# Altium schematic sheet sem02_2442sd.SchDoc
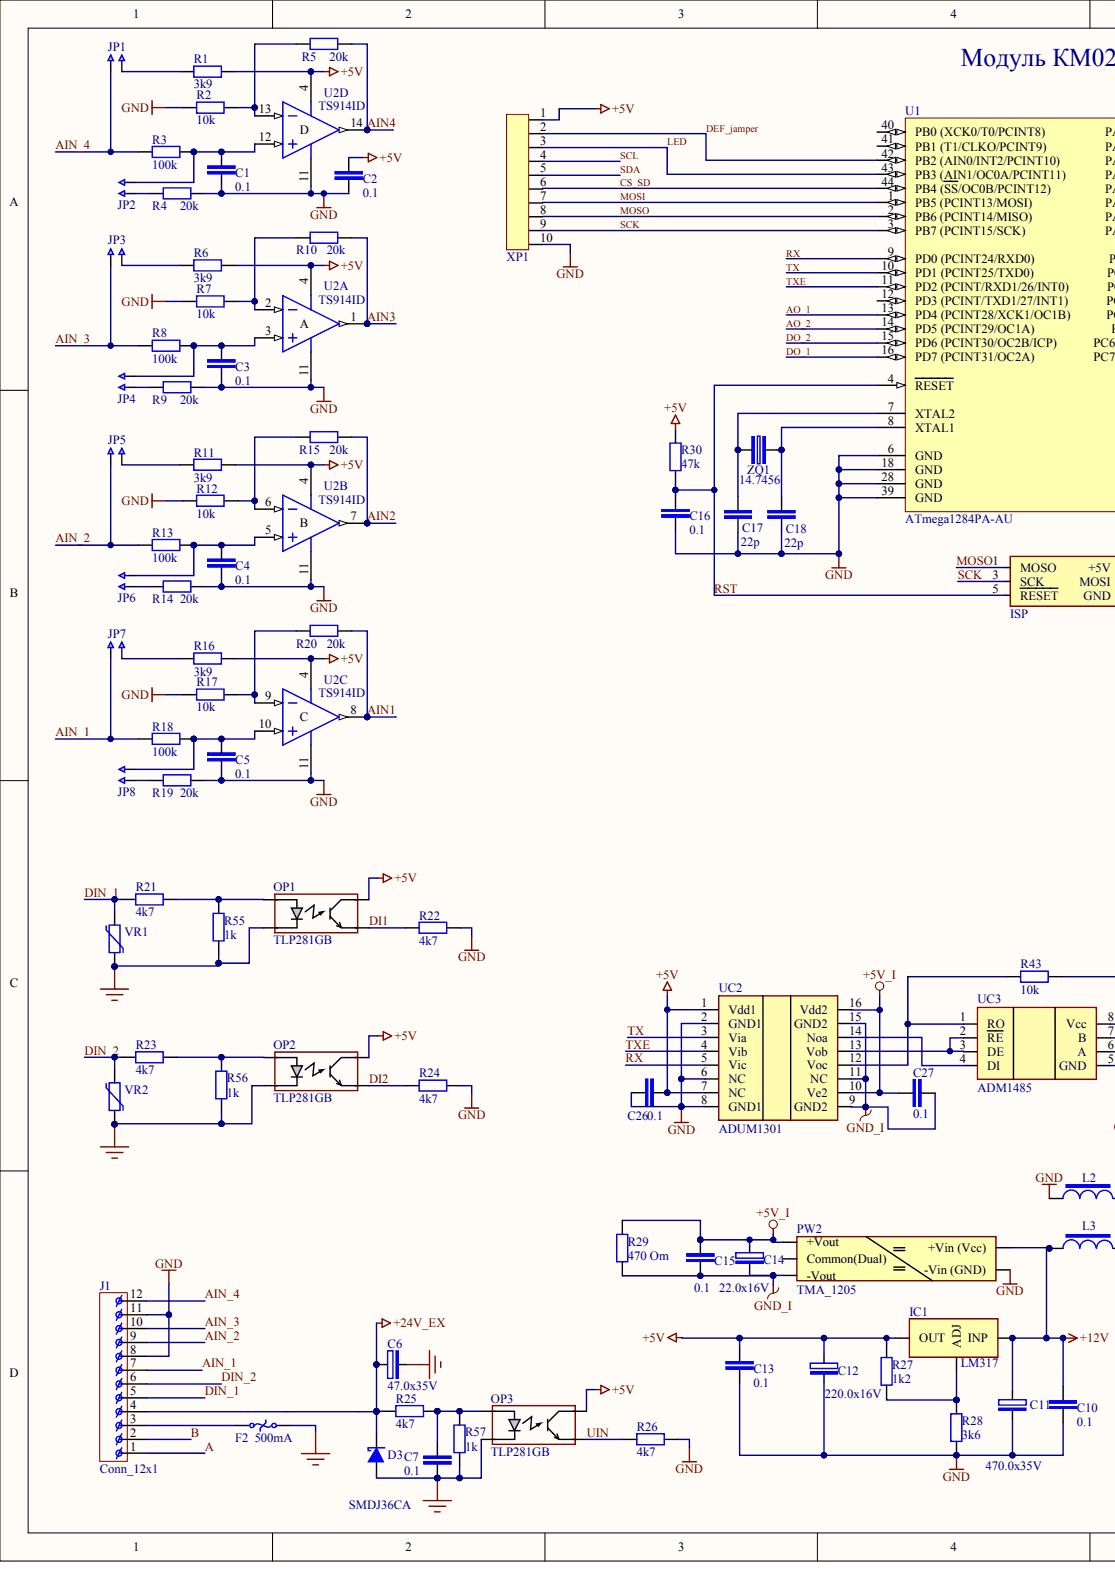
[diagram p0: sem02_2442sd.SchDoc, left]
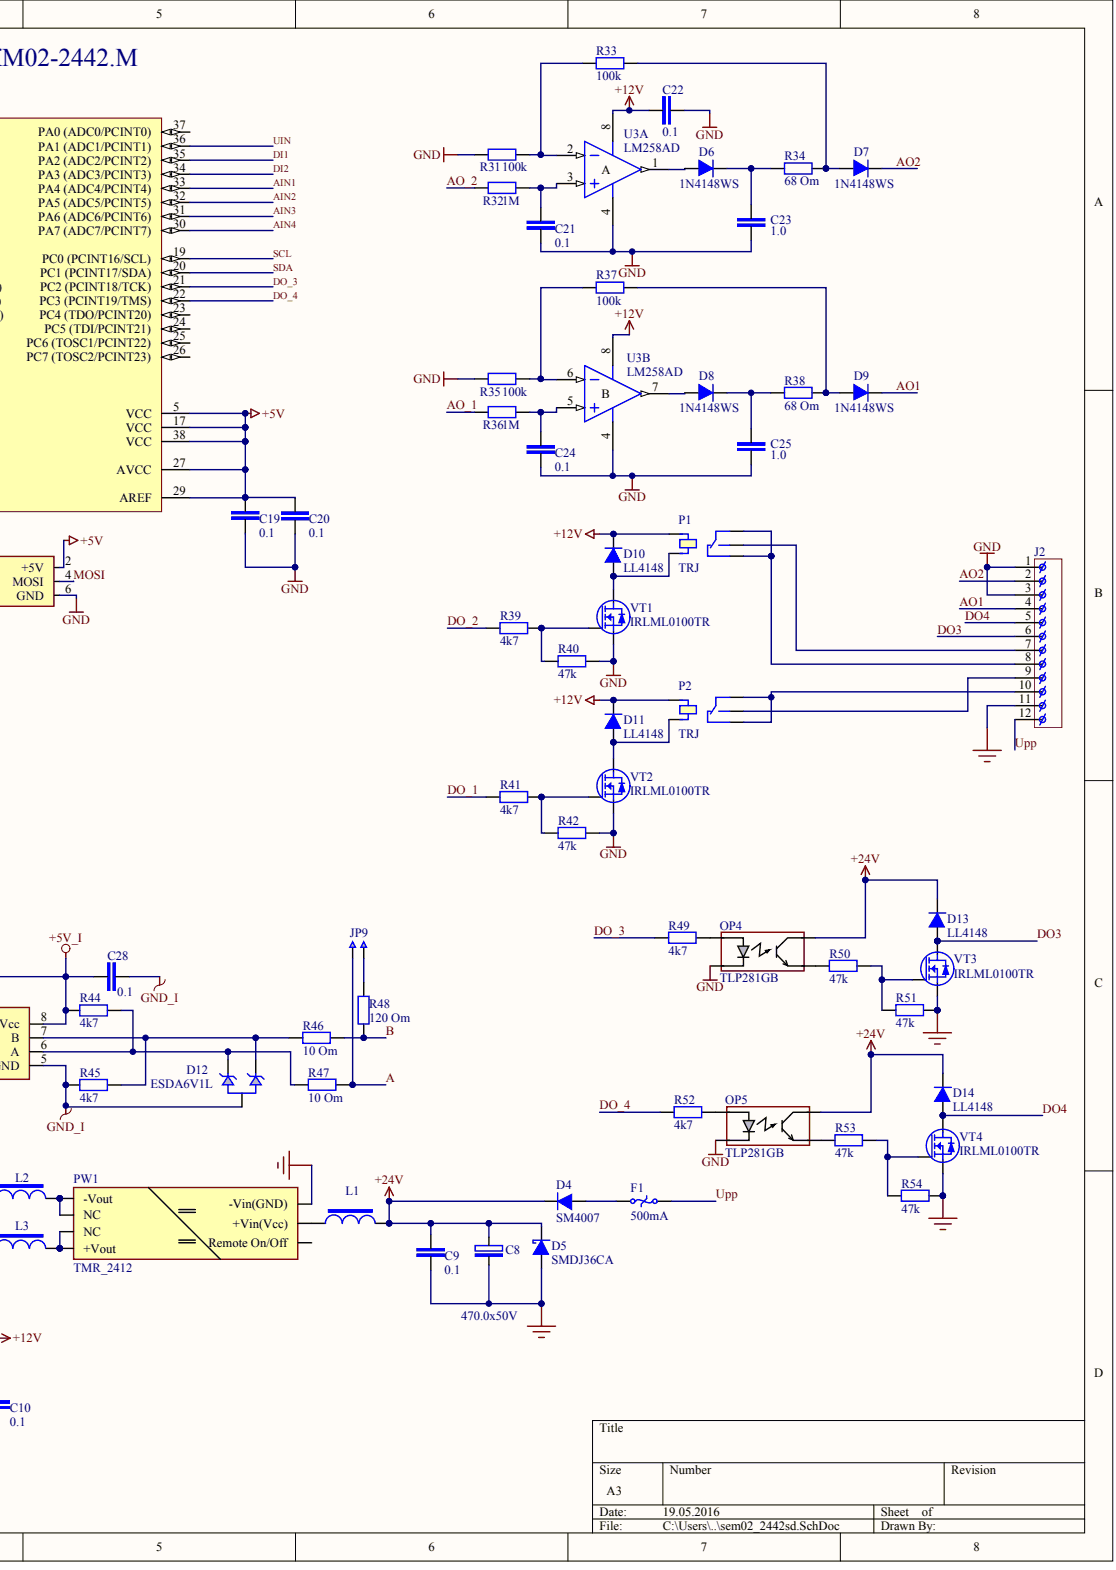
[diagram p1: sem02_2442sd.SchDoc, right]

## Parts
C1  0.1
C2  0.1
C3  0.1
C4  0.1
C5  0.1
C6  47.0x35V
C7  0.1
C8  470.0x50V
C9  0.1
C10  0.1
C11  470.0x35V
C12  220.0x16V
C13  0.1
C14  22.0x16V
C15  0.1
C16  0.1
C17  22p
C18  22p
C19  0.1
C20  0.1
C21  0.1
C22  0.1
C23  1.0
C24  0.1
C25  1.0
C26  0.1  (value not drawn)
C27  0.1
C28  0.1
D3  SMDJ36CA
D4  SM4007
D5  SMDJ36CA
D6  1N4148WS
D7  1N4148WS
D8  1N4148WS
D9  1N4148WS
D10  LL4148
D11  LL4148
D12  ESDA6V1L
D13  LL4148
D14  LL4148
F1  500mA
F2  500mA
IC1  LM317
ISP  ISP
J1  Conn_12x1
J2  Conn_12x1
JP1  jamper  (value not drawn)
JP2  jamper  (value not drawn)
JP3  jamper  (value not drawn)
JP4  jamper  (value not drawn)
JP5  jamper  (value not drawn)
JP6  jamper  (value not drawn)
JP7  jamper  (value not drawn)
JP8  jamper  (value not drawn)
JP9  jamper  (value not drawn)
L1  LDR  (value not drawn)
L2  LDR  (value not drawn)
L3  LDR  (value not drawn)
OP1  TLP281GB
OP2  TLP281GB
OP3  TLP281GB
OP4  TLP281GB
OP5  TLP281GB
P1  TRJ
P2  TRJ
PW1  TMR_2412
PW2  TMA_1205
R1  3k9
R2  10k
R3  100k
R4  20k
R5  20k
R6  3k9
R7  10k
R8  100k
R9  20k
R10  20k
R11  3k9
R12  10k
R13  100k
R14  20k
R15  20k
R16  3k9
R17  10k
R18  100k
R19  20k
R20  20k
R21  4k7
R22  4k7
R23  4k7
R24  4k7
R25  4k7
R26  4k7
R27  1k2
R28  3k6
R29  470 Om
R30  47k
R31  100k
R32  1M  (value not drawn)
R33  100k
R34  68 Om
R35  100k
R36  1M  (value not drawn)
R37  100k
R38  68 Om
R39  4k7
R40  47k
R41  4k7
R42  47k
R43  10k
R44  4k7
R45  4k7
R46  10 Om
R47  10 Om
R48  120 Om
R49  4k7
R50  47k
R51  47k
R52  4k7
R53  47k
R54  47k
R55  1k
R56  1k
R57  1k
U1  ATmega1284PA-AU
U2  TS914ID  (parts A,B,C,D)
U3  LM258AD  (parts A,B)
UC2  ADUM1301
UC3  ADM1485
VR1  CN1206K25G  (value not drawn)
VR2  CN1206K25G  (value not drawn)
VT1  IRLML0100TR
VT2  IRLML0100TR
VT3  IRLML0100TR
VT4  IRLML0100TR
XP1  Conn10x1  (value not drawn)
ZQ1  14.7456

## Nets
+5V: C2.1 C12.1 C13.1 C19.1 C20.1 C26.1 IC1.2 ISP.2(+5V) OP1.4 OP2.4 OP3.4 R1.1 R6.1 R11.1 R16.1 R27.2 R30.2 U1.5(VCC) U1.17(VCC) U1.27(AVCC) U1.29(AREF) U1.38(VCC) U2.4 UC2.1(Vdd1) UC2.7(NC) XP1.1
+5V_I: C14.1 C15.1 C27.1 C28.2 PW2.5(+Vout) R29.1 R43.1 R44.2 UC2.10(Ve2) UC2.16(Vdd2) UC3.8(Vcc)
+12V: C10.1 C11.1 C22.1 D10.2 D11.2 IC1.3 L3.2 P1.1 P2.1 PW2.1(+Vin_(Vcc)) U3.8
+24V: C8.1 C9.1 D4.2 D5.1 D13.2 D14.2 L1.1 OP4.4 OP5.4
+24V_EX: C6.1 D3.1 J1.4 R25.1
GND: C1.2 C2.2 C3.2 C4.2 C5.2 C10.2 C11.2 C12.2 C13.2 C16.2 C17.2 C18.2 C19.2 C20.2 C21.2 C22.2 C23.2 C24.2 C25.2 C26.2 ISP.6(GND) J1.8 J1.11 J2.1 J2.3 L2.2 OP4.2 OP5.2 PW2.2(-Vin_(GND)) R2.2 R4.1 R7.2 R9.1 R12.2 R14.1 R17.2 R19.1 R22.2 R24.2 R26.2 R28.1 R31.2 R35.2 R40.1 R42.1 U1.6(GND) U1.18(GND) U1.28(GND) U1.39(GND) U2.11 U3.4 UC2.2(GND1) UC2.6(NC) UC2.8(GND1) VT1.2 VT2.2 XP1.10
GND_EX: C6.2 C7.2 D3.2 F2.2 OP1.2 OP2.2 OP3.2 R55.1 R56.1 R57.1 VR1.1 VR2.1
GND_I: C14.2 C15.2 C27.2 C28.1 D12.3 PW2.3(-Vout) R29.2 R45.2 UC2.9(GND2) UC2.11(NC) UC2.15(GND2) UC3.5(GND)
GND_UPP: C8.2 C9.2 D5.2 J2.11 PW1.1(-Vin(GND)) R51.1 R54.1 VT3.2 VT4.2
A: J1.1 JP9.1 R47.1
AIN1: R20.1 U1.33(PA4_(ADC4/PCINT4)) U2.8
AIN2: R15.1 U1.32(PA5_(ADC5/PCINT5)) U2.7
AIN3: R10.1 U1.31(PA6_(ADC6/PCINT6)) U2.1
AIN4: R5.1 U1.30(PA7_(ADC7/PCINT7)) U2.14
AIN_1: J1.7 JP7.1 R18.2
AIN_2: J1.9 JP5.1 R13.2
AIN_3: J1.10 JP3.1 R8.2
AIN_4: J1.12 JP1.1 R3.2
AO1: D9.2 J2.4
AO2: D7.2 J2.2
AO_1: R36.2 U1.13(PD4_(PCINT28/XCK1/OC1B))
AO_2: R32.2 U1.14(PD5_(PCINT29/OC1A))
B: J1.2 R46.1 R48.1
CS_SD: U1.44(PB4_(SS/OC0B/PCINT12)) XP1.6
DEF_JAMPER: U1.42(PB2_(AIN0/INT2/PCINT10)) XP1.2
DI1: OP1.3 R22.1 U1.35(PA2_(ADC2/PCINT2))
DI2: OP2.3 R24.1 U1.34(PA3_(ADC3/PCINT3))
DIN_1: J1.5 R21.1 VR1.2
DIN_2: J1.6 R23.1 VR2.2
DO3: D13.1 J2.6 VT3.3
DO4: D14.1 J2.5 VT4.3
DO_1: R41.2 U1.16(PD7_(PCINT31/OC2A))
DO_2: R39.2 U1.15(PD6_(PCINT30/OC2B/ICP))
DO_3: R49.2 U1.21(PC2_(PCINT18/TCK))
DO_4: R52.2 U1.22(PC3_(PCINT19/TMS))
LED: U1.43(PB3_(AIN1/OC0A/PCINT11)) XP1.3
MOSI: ISP.4(MOSI) U1.1(PB5_(PCINT13/MOSI)) XP1.7
MOSO: ISP.1(MOSO) U1.2(PB6_(PCINT14/MISO)) XP1.8
RST: C16.1 ISP.5(RESET) R30.1 U1.4(RESET)
RX: U1.9(PD0_(PCINT24/RXD0)) UC2.5(Vic)
SCK: ISP.3(SCK) U1.3(PB7_(PCINT15/SCK)) XP1.9
SCL: U1.19(PC0_(PCINT16/SCL)) XP1.4
SDA: U1.20(PC1_(PCINT17/SDA)) XP1.5
TX: U1.10(PD1_(PCINT25/TXD0)) UC2.3(Via)
TXE: U1.11(PD2_(PCINT/RXD1/26/INT0)) UC2.4(Vib)
UIN: OP3.3 R26.1 U1.36(PA1_(ADC1/PCINT1))
UPP: F1.2 J2.12
NetC1_1: C1.1 JP2.2 R3.1 U2.12
NetC3_1: C3.1 JP4.2 R8.1 U2.3
NetC4_1: C4.1 JP6.2 R13.1 U2.5
NetC5_1: C5.1 JP8.2 R18.1 U2.10
NetC7_1: C7.1 OP3.1 R25.2 R57.2
NetC17_1: C17.1 U1.7(XTAL2) ZQ1.1
NetC18_1: C18.1 U1.8(XTAL1) ZQ1.2
NetC21_1: C21.1 R32.1 U3.3
NetC23_1: C23.1 D6.2 R34.2
NetC24_1: C24.1 R36.1 U3.5
NetC25_1: C25.1 D8.2 R38.2
NetD4_1: D4.1 F1.1
NetD6_1: D6.1 U3.1
NetD7_1: D7.1 R33.1 R34.1
NetD8_1: D8.1 U3.7
NetD9_1: D9.1 R37.1 R38.1
NetD10_1: D10.1 P1.5 VT1.3
NetD11_1: D11.1 P2.5 VT2.3
NetD12_1: D12.1 R45.1 R46.2 UC3.7(B)
NetD12_2: D12.2 R44.1 R47.2 UC3.6(A)
NetF2_1: F2.1 J1.3
NetIC1_1: IC1.1 R27.1 R28.2
NetJ2_7: J2.7 P1.3
NetJ2_8: J2.8 P1.2 P1.4
NetJ2_9: J2.9 P2.3
NetJ2_10: J2.10 P2.2 P2.4
NetJP1_2: JP1.2 R1.2
NetJP2_1: JP2.1 R4.2
NetJP3_2: JP3.2 R6.2
NetJP4_1: JP4.1 R9.2
NetJP5_2: JP5.2 R11.2
NetJP6_1: JP6.1 R14.2
NetJP7_2: JP7.2 R16.2
NetJP8_1: JP8.1 R19.2
NetJP9_2: JP9.2 R48.2
NetL1_2: L1.2 PW1.2(+Vin(Vcc))
NetL2_1: L2.1 PW1.6(NC) PW1.7(-Vout)
NetL3_1: L3.1 PW1.4(+Vout) PW1.5(NC)
NetOP1_1: OP1.1 R21.2 R55.2
NetOP2_1: OP2.1 R23.2 R56.2
NetOP4_1: OP4.1 R49.1
NetOP4_3: OP4.3 R50.2
NetOP5_1: OP5.1 R52.1
NetOP5_3: OP5.3 R53.2
NetR2_1: R2.1 R5.2 U2.13
NetR7_1: R7.1 R10.2 U2.2
NetR12_1: R12.1 R15.2 U2.6
NetR17_1: R17.1 R20.2 U2.9
NetR31_1: R31.1 R33.2 U3.2
NetR35_1: R35.1 R37.2 U3.6
NetR39_1: R39.1 R40.2 VT1.1
NetR41_1: R41.1 R42.2 VT2.1
NetR43_2: R43.2 UC2.12(Voc) UC3.1(RO)
NetR50_1: R50.1 R51.2 VT3.1
NetR53_1: R53.1 R54.2 VT4.1
NetUC2_13: UC2.13(Vob) UC3.2(RE) UC3.3(DE)
NetUC2_14: UC2.14(Noa) UC3.4(DI)

Not connected: PW1 3(Remote_On/Off); PW2 4(Common(Dual)); U1 12(PD3_(PCINT/TXD1/27/INT1)) 23(PC4_(TDO/PCINT20)) 24(PC5_(TDI/PCINT21)) 25(PC6_(TOSC1/PCINT22)) 26(PC7_(TOSC2/PCINT23)) 37(PA0_(ADC0/PCINT0)) 40(PB0_(XCK0/T0/PCINT8)) 41(PB1_(T1/CLKO/PCINT9))

## Library data of the parts
C1-C28 D3-D14 F1 F2 IC1 ISP J1 J2 JP1-JP9 L1-L3 OP1-OP5 P1 P2 PW1 PW2 R1-R57 U1-U3 UC2 UC3 VR1 VR2 VT1-VT4 XP1 ZQ1 = (no symbol name)
  U1: Published: 1-Apr-2010; DatasheetVersion: revA, Jan-2010; PackageVersion: rev.B, Oct-2001; PackageReference: 44A; PackageDescription: 44-Pin Plastic Thin Profile Quad Flat Pack, 10 x 10 x 1.0 mm Body, 0.8 mm Pitch (TQFP); Supplier 1: Digi-Key; Supplier Part Number 1: ATMEGA164PA-AU-ND; Supplier 2: Farnell; Supplier Part Number 2: 1748513; Supplier 3: Mouser; Supplier Part Number 3: 556-ATMEGA164PA-AU; ComponentLink1Description: Manufacturer Link; ComponentLink1URL: http://www.atmel.com/products/avr/default.asp?; ComponentLink2Description: ATmega164A/164PA/324A/324PA/644A/644PA/1284/1284P Datasheet; ComponentLink2URL: http://www.atmel.com/dyn/resources/prod_documents/doc8272.pdf; ComponentLink3Description: ATmega164A/164PA/324A/324PA/644A/644PA/1284/1284P Summary; ComponentLink3URL: http://www.atmel.com/dyn/resources/prod_documents/8272S.pdf; ComponentLink4Description: Atmel.com Home; ComponentLink4URL: http://www.atmel.com
  U2: Published: 24-Aug-2001; LatestRevisionDate: 13-Apr-2006; LatestRevisionNote: IPC-7351 Footprint Added.; DatasheetVersion: Apr-1999; PackageVersion: Sep-1998; PackageReference: SO14; PackageDescription: 14-Pin Small Outline Integrated Circuit 1.27 mm Pitch; Code_IPC: SOIC127P600-14; ComponentLink1Description: Manufacturer Link; ComponentLink1URL: http://www.st.com/; ComponentLink2Description: Datasheet; ComponentLink2URL: http://www.st.com/stonline/products/literature/ds/4475/ts914.pdf
  U3: Published: 24-Aug-2001; LatestRevisionDate: 13-Apr-2006; LatestRevisionNote: IPC-7351 Footprint Added.; DatasheetVersion: Jun-1998; PackageVersion: Dec-2002; PackageReference: SO8; PackageDescription: 8-Pin Small Outline Integrated Circuit 1.27 mm Pitch; Code_JEDEC: MS-012-AA; Code_IPC: SOIC127P600-8; ComponentLink1Description: Manufacturer Link; ComponentLink1URL: http://www.st.com/; ComponentLink2Description: Datasheet; ComponentLink2URL: http://www.st.com/stonline/products/literature/ds/2163/lm358.pdf
  U1-U3: Publisher: Altium Limited
